# Altium schematic sheet Input Power Split Circuit.SchDoc
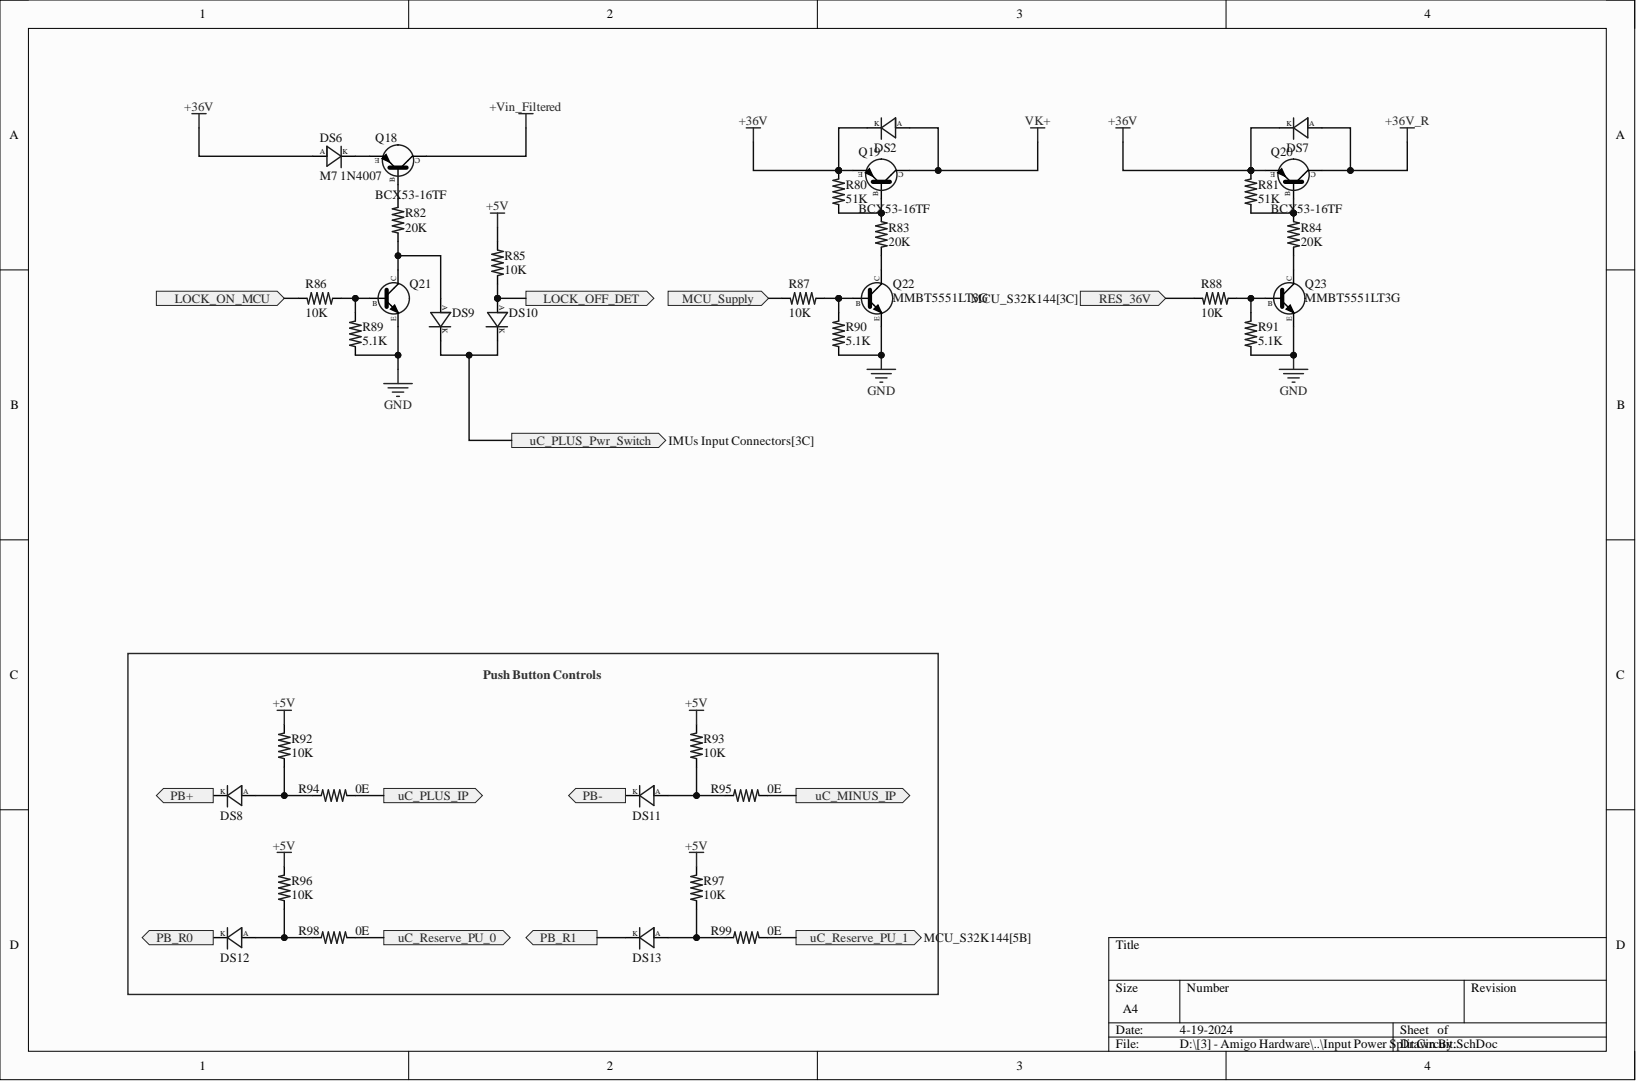
[diagram p0: Input Power Split Circuit.SchDoc]

## Parts
DS2  BAS416-HF  [SOD-323]  (value not drawn)
DS6  M7 1N4007  [DIO214AC]
DS7  BAS416-HF  [SOD-323]  (value not drawn)
DS8  BAS416-HF  [SOD-323]  (value not drawn)
DS9  BAS416-HF  [SOD-323]  (value not drawn)
DS10  BAS416-HF  [SOD-323]  (value not drawn)
DS11  BAS416-HF  [SOD-323]  (value not drawn)
DS12  BAS416-HF  [SOD-323]  (value not drawn)
DS13  BAS416-HF  [SOD-323]  (value not drawn)
Q18  BCX53-16TF  [SOT89]
Q19  BCX53-16TF  [SOT89]
Q20  BCX53-16TF  [SOT89]
Q21  MMBT5551LT3G  [SOT23]
Q22  MMBT5551LT3G  [SOT23]
Q23  MMBT5551LT3G  [SOT23]
R80  51K  [RES_0603]
R81  51K  [RES_0603]
R82  20K  [RES_0603]
R83  20K  [RES_0603]
R84  20K  [RES_0603]
R85  10K  [RES_0603]
R86  10K  [RES_0603]
R87  10K  [RES_0603]
R88  10K  [RES_0603]
R89  5.1K  [RES_0603]
R90  5.1K  [RES_0603]
R91  5.1K  [RES_0603]
R92  10K  [RES_0603]
R93  10K  [RES_0603]
R94  0E  [RES_0603]
R95  0E  [RES_0603]
R96  10K  [RES_0603]
R97  10K  [RES_0603]
R98  0E  [RES_0603]
R99  0E  [RES_0603]

## Ports
LOCK_OFF_DET, LOCK_ON_MCU, MCU_Supply, PB+, PB-, PB_R0, PB_R1, RES_36V, uC_MINUS_IP, uC_PLUS_IP, uC_PLUS_Pwr_Switch, uC_Reserve_PU_0, uC_Reserve_PU_1

## Nets
+5V: R85.1 R92.2 R93.2 R96.2 R97.2
+36V: DS2.2 DS6.1 DS7.2 Q19.3 Q20.3 R80.2 R81.2
+36V_R: DS7.1 Q20.2,4
+Vin_Filtered: Q18.2,4
GND: Q21.2 Q22.2 Q23.2 R89.1 R90.1 R91.1
VK+: DS2.1 Q19.2,4
LOCK_OFF_DET: DS10.1 R85.2 ; port LOCK_OFF_DET
LOCK_ON_MCU: R86.2 ; port LOCK_ON_MCU
MCU_Supply: R87.2 ; port MCU_Supply
PB_R0: DS12.2 ; port PB_R0
PB_R1: DS13.2 ; port PB_R1
RES_36V: R88.2 ; port RES_36V
uC_MINUS_IP: R95.2 ; port uC_MINUS_IP
uC_PLUS_IP: R94.2 ; port uC_PLUS_IP
uC_PLUS_Pwr_Switch: DS9.2 DS10.2 ; port uC_PLUS_Pwr_Switch
uC_Reserve_PU_0: R98.2 ; port uC_Reserve_PU_0
uC_Reserve_PU_1: R99.2 ; port uC_Reserve_PU_1
NetDS6_2: DS6.2 Q18.3
NetDS8_1: DS8.1 R92.1 R94.1
NetDS8_2: DS8.2
NetDS9_1: DS9.1 Q21.3 R82.1
NetDS11_1: DS11.1 R93.1 R95.1
NetDS11_2: DS11.2
NetDS12_1: DS12.1 R96.1 R98.1
NetDS13_1: DS13.1 R97.1 R99.1
NetQ18_1: Q18.1 R82.2
NetQ19_1: Q19.1 R80.1 R83.1
NetQ20_1: Q20.1 R81.1 R84.1
NetQ21_1: Q21.1 R86.1 R89.2
NetQ22_1: Q22.1 R87.1 R90.2
NetQ22_3: Q22.3 R83.2
NetQ23_1: Q23.1 R88.1 R91.2
NetQ23_3: Q23.3 R84.2
~{}:

## Library data of the parts
DS2 DS7-DS13 = DIO_REC_100V_200mA_SOD-323: Diode Switching 85V 200MA 250MW; Manufacturer: Comchip; Component Kind: Standard; Library Name: EM_LIBRARY_1.IntLib; Manufacturer Part Number: BAS416-HF; Pricing: 1=0.21, 10=0.146, 100=0.0711, 500=0.0593, 1000=0.04121 (USD); Stock: 2730; Supplier: DigiKey; Supplier Part Number: 641-BAS416-HFCT-ND
DS6 = DIO_RECT_1000V_1A_DIO214AC: Diode 1000 V 1A Surface Mount SMA/DO-214AC; Component Kind: Standard; Library Name: EM_LIBRARY_1.IntLib
Q18-Q20 = TRANS_PNP-80V_1A_SOT89: Transistor Gp Bjt PNP 80V 1A 3-PIN SC-62 T/r; Manufacturer: Nexperia; Category: Transistors; Component Kind: Standard; Library Name: EM_LIBRARY_1.IntLib; Manufacturer Part Number: BCX53-16TF; Pricing 1: 1=0.39, 10=0.276, 100=0.1394, 500=0.12356, 1000=0.09615, 2000=0.08606 (USD); RoHS: No; Stock 1: 14092; Supplier 1: Digi-Key; Supplier Part Number 1: 1727-BCX53-16TFCT-ND
Q21-Q23 = TRANS_NPN_160V_600mA_SOT23: NPN Ic=600mA Vceo=160V hfe=80~250 P=225mW SOT-23; Manufacturer: ON Semiconductor; Collector Base Voltage (VCBO): 180V; Collector Emitter Breakdown Voltage: 160V; Collector Emitter Saturation Voltage: 150mV; Collector-emitter Voltage-Max: 200mV; Current Rating: 600mA; Emitter Base Voltage (VEBO): 6V; hFE Min: 80; Max Power Dissipation: 225mW; Number of Elements: 1; Power Dissipation: 300mW; Case/Package: SOT-23-3; Category: BJTs; Collector Emitter Voltage (VCEO): 160V; Component Kind: Standard; ComponentLink1Description: https://datasheet.ciiva.com/4721/mmbt5550lt1-d-111393-4721331.pdf?src-supplier=Mouser; ComponentLink1URL: https://datasheet.ciiva.com/4721/mmbt5550lt1-d-111393-4721331.pdf?src-supplier=Mouser; ComponentLink2Description: https://datasheet.ciiva.com/15138/getdatasheetpartid-69307-15138969.pdf?src-supplier=Verical; ComponentLink2URL: https://datasheet.ciiva.com/15138/getdatasheetpartid-69307-15138969.pdf?src-supplier=Verical; ComponentLink3Description: https://datasheet.ciiva.com/46624/mmbt5550lt1-d-46624373.pdf?src-supplier=Digi-Key; ComponentLink3URL: https://datasheet.ciiva.com/46624/mmbt5550lt1-d-46624373.pdf?src-supplier=Digi-Key; Height: 1.11mm; Lead Free: Lead Free; Length: 3.04mm; Library Name: EM_LIBRARY_1.IntLib; Manufacturer Part Number: MMBT5551LT3G; Max Breakdown Voltage: 160V; Max Collector Current: 600mA; Max Operating Temperature: 150°C; Min Operating Temperature: -55°C; Number of Pins: 3; Package Quantity: 10000; Packaging: Tape and Reel; Polarity: NPN; Pricing 1: 1=0.17, 10=0.12, 100=0.065, 500=0.05104, 1000=0.03545, 2000=0.02937, 5000=0.02777 (USD); Radiation Hardening: No; RoHS: Yes; RoHS Compliant: Yes; Series: -; Stock 1: 6650; Supplier 1: Digi-Key; Supplier Part Number 1: MMBT5551LT3GOSCT-ND; Voltage Rating (DC): 160V; Width: 2.64mm
R80 R81 = RES_51K_0.1W_1%_0603: Fixed Resistor, Metal Glaze/thick Film, 0.1W, 51000ohm, 75V, 1% +/-Tol, 100ppm/Cel, Surface Mount, 0603; Manufacturer: Yageo; Manufacturer Part Number: RC0603FR-0751KL; Additional Feature: LASER TRIMMABLE; Package Height: 0.45mm; Package Length: 1.6mm; Package Width: 0.8mm; Power: 100mW; Rated Temperature: 70°C; Temperature Coefficient: 100ppm/°C; Value: 51kR; Case/Package: 0603; Category: Chip SMD Resistors; Component Kind: Standard; ComponentLink1Description: https://datasheet.ciiva.com:443/pdfs/VipMasterIC/IC/YAGO/YAGO-S-A0008145938/YAGO-S-A0008145938-1.pdf?src-supplier=IHS+Markit; ComponentLink1URL: https://datasheet.ciiva.com:443/pdfs/VipMasterIC/IC/YAGO/YAGO-S-A0008145938/YAGO-S-A0008145938-1.pdf?src-supplier=IHS+Markit; ComponentLink2Description: https://datasheet.ciiva.com/11819/0900766b80f96399-11819378.pdf?src-supplier=Rs; ComponentLink2URL: https://datasheet.ciiva.com/11819/0900766b80f96399-11819378.pdf?src-supplier=Rs; ComponentLink3Description: https://datasheet.ciiva.com/46395/443971-46395014.pdf?src-supplier=Element14; ComponentLink3URL: https://datasheet.ciiva.com/46395/443971-46395014.pdf?src-supplier=Element14; ComponentLink4Description: https://datasheet.ciiva.com/2454/pyu-r-int-thick-7-2454124.pdf?src-supplier=Digi-Key; ComponentLink4URL: https://datasheet.ciiva.com/2454/pyu-r-int-thick-7-2454124.pdf?src-supplier=Digi-Key; ComponentLink5Description: https://datasheet.ciiva.com/2454/pyu-r-int-thick-7-2454125.pdf?src-supplier=Digi-Key; ComponentLink5URL: https://datasheet.ciiva.com/2454/pyu-r-int-thick-7-2454125.pdf?src-supplier=Digi-Key; ComponentLink6Description: https://datasheet.ciiva.com/2454/pyu-r-int-thick-7-2454123.pdf?src-supplier=Digi-Key; ComponentLink6URL: https://datasheet.ciiva.com/2454/pyu-r-int-thick-7-2454123.pdf?src-supplier=Digi-Key; ComponentLink7Description: https://datasheet.ciiva.com/12558/rc0603-272842-12558554.pdf?src-supplier=Mouser; ComponentLink7URL: https://datasheet.ciiva.com/12558/rc0603-272842-12558554.pdf?src-supplier=Mouser; Construction: Chip; JESD-609 Code: e3; Library Name: EM_LIBRARY_1.IntLib; Max Operating Temperature: 155°C; Min Operating Temperature: -55°C; Mounting Technology: Surface Mount; Package Shape: Rectangular; Package Style: SMTMeter; Packaging: Tape and Reel; Pins: 2; Pricing: 1=0.1, 10=0.019, 50=0.0112, 100=0.0093, 500=0.00622, 1000=0.00532 (USD); Reach SVHC Compliant: Yes; Resistor Type: FIXED RESISTOR; RoHS: Yes; RoHS Compliant: Yes; Stock: 1453302; Supplier: Digi-Key; Supplier Part Number: 311-51.0KHRCT-ND; Technology: METAL GLAZE/THICK FILM; Terminal Finish: Nickel; Termination: Wraparound; Tolerance: 1%; Working Voltage: 75V
R82-R84 = RES_20K_1/10W_1%_0603: SMD Chip Resistor, 20 kOhm, ± 1%, 100 mW, 0603 [1608 Metric], Thick Film, General Purpose; Manufacturer 1: Yageo; Manufacturer Part Number 1: RC0603FR-1320KL; Category: Chip SMD Resistors; Component Kind: Standard; ComponentLink1Description: https://datasheet.ciiva.com/12561/rc0603-272842-12561998.pdf?src-supplier=Mouser; ComponentLink1URL: https://datasheet.ciiva.com/12561/rc0603-272842-12561998.pdf?src-supplier=Mouser; Library Name: EM_LIBRARY_1.IntLib; Pricing 1: 1=0.1, 10=0.018, 50=0.0106, 100=0.0088, 500=0.00592, 1000=0.00505, 5000=0.00363, 10000=0.00318 (USD); RoHS: Yes; Stock 1: 29997; Supplier 1: Digi-Key; Supplier Part Number 1: 13-RC0603FR-1320KLCT-ND
R85-R88 R92 R93 R96 R97 = RES_10K_0.1W_1%_0603: Fixed Resistor, Metal Glaze/thick Film, 0.1W, 10000ohm, 75V, 1% +/-Tol, 100ppm/Cel, Surface Mount, 0603; Manufacturer: Yageo; Manufacturer Part Number: RC0603FR-0710KL; Additional Feature: LASER TRIMMABLE; Package Height: 0.45mm; Package Length: 1.6mm; Package Width: 0.8mm; Power: 100mW; Rated Temperature: 70°C; Temperature Coefficient: 100ppm/°C; Value: 10kR; Case/Package: 0603; Category: Chip SMD Resistors; Component Kind: Standard; ComponentLink1Description: https://datasheet.ciiva.com:443/pdfs/VipMasterIC/IC/YAGO/YAGO-S-A0008145938/YAGO-S-A0008145938-1.pdf?src-supplier=IHS+Markit; ComponentLink1URL: https://datasheet.ciiva.com:443/pdfs/VipMasterIC/IC/YAGO/YAGO-S-A0008145938/YAGO-S-A0008145938-1.pdf?src-supplier=IHS+Markit; ComponentLink2Description: https://datasheet.ciiva.com/46440/1868787-46440891.pdf?src-supplier=Element14; ComponentLink2URL: https://datasheet.ciiva.com/46440/1868787-46440891.pdf?src-supplier=Element14; ComponentLink3Description: https://datasheet.ciiva.com/7746/1738695-7746239.pdf?src-supplier=Element14; ComponentLink3URL: https://datasheet.ciiva.com/7746/1738695-7746239.pdf?src-supplier=Element14; ComponentLink4Description: https://datasheet.ciiva.com/7983/443971-7983596.pdf?src-supplier=Element14; ComponentLink4URL: https://datasheet.ciiva.com/7983/443971-7983596.pdf?src-supplier=Element14; ComponentLink5Description: https://datasheet.ciiva.com/7660/38590-7660789.pdf?src-supplier=Element14; ComponentLink5URL: https://datasheet.ciiva.com/7660/38590-7660789.pdf?src-supplier=Element14; ComponentLink6Description: https://datasheet.ciiva.com/22747/443971-22747519.pdf?src-supplier=Element14; ComponentLink6URL: https://datasheet.ciiva.com/22747/443971-22747519.pdf?src-supplier=Element14; ComponentLink7Description: https://datasheet.ciiva.com/15189/getdatasheetpartid-409289-15189591.pdf?src-supplier=Verical; ComponentLink7URL: https://datasheet.ciiva.com/15189/getdatasheetpartid-409289-15189591.pdf?src-supplier=Verical; Construction: Chip; JESD-609 Code: e3; Library Name: EM_LIBRARY_1.IntLib; Max Operating Temperature: 155°C; Min Operating Temperature: -55°C; Mounting Technology: Surface Mount; Package Shape: Rectangular; Package Style: SMTMeter; Packaging: Tape and Reel; Pins: 2; Pricing: 1=0.1, 10=0.019, 50=0.0112, 100=0.0093, 500=0.00622, 1000=0.00532 (USD); Reach SVHC Compliant: Yes; Resistor Type: FIXED RESISTOR; RoHS: Yes; RoHS Compliant: Yes; Stock: 24542638; Supplier: Digi-Key; Supplier Part Number: 311-10.0KHRDKR-ND; Technology: METAL GLAZE/THICK FILM; Terminal Finish: Nickel; Termination: Wraparound; Tolerance: 1%; Working Voltage: 75V
R89-R91 = RES_5.1K_1/10W_5%_0603: SMD Chip Resistor, 5.1 kOhm, ± 5%, 100 mW, 0603 [1608 Metric], Thick Film, General Purpose; Manufacturer 1: Yageo; Manufacturer Part Number 1: RC0603JR-075K1L; Category: Chip SMD Resistors; Component Kind: Standard; ComponentLink1Description: http://www.farnell.com/datasheets/2167052.pdf?src-supplier=Farnell; ComponentLink1URL: http://www.farnell.com/datasheets/2167052.pdf?src-supplier=Farnell; ComponentLink2Description: http://www.mouser.com/ds/2/447/RC0603-272842.pdf?src-supplier=Mouser; ComponentLink2URL: http://www.mouser.com/ds/2/447/RC0603-272842.pdf?src-supplier=Mouser; ComponentLink3Description: http://www.farnell.com/datasheets/2167052.pdf?src-supplier=Element14; ComponentLink3URL: http://www.farnell.com/datasheets/2167052.pdf?src-supplier=Element14; ComponentLink4Description: http://www.farnell.com/datasheets/1868787.pdf?src-supplier=Newark; ComponentLink4URL: http://www.farnell.com/datasheets/1868787.pdf?src-supplier=Newark; ComponentLink5Description: https://datasheet.octopart.com/RC0603JR-075K1L-Yageo-datasheet-34211833.pdf?src-supplier=Element14; ComponentLink5URL: https://datasheet.octopart.com/RC0603JR-075K1L-Yageo-datasheet-34211833.pdf?src-supplier=Element14; ComponentLink6Description: https://datasheet.octopart.com/RC0603JR-075K1L-Yageo-datasheet-62067350.pdf?src-supplier=Farnell; ComponentLink6URL: https://datasheet.octopart.com/RC0603JR-075K1L-Yageo-datasheet-62067350.pdf?src-supplier=Farnell; ComponentLink7Description: http://www.farnell.com/datasheets/2167052.pdf?src-supplier=Newark; ComponentLink7URL: http://www.farnell.com/datasheets/2167052.pdf?src-supplier=Newark; ComponentLink8Description: http://www.yageo.com/documents/recent/PYu-RC_Group_51_RoHS_L_10.pdf?src-supplier=Digi-Key; ComponentLink8URL: http://www.yageo.com/documents/recent/PYu-RC_Group_51_RoHS_L_10.pdf?src-supplier=Digi-Key; ComponentLink9Description: https://datasheet.octopart.com/RC0603JR-075K1L-Yageo-datasheet-164759695.pdf?src-supplier=Future+Electronics; ComponentLink9URL: https://datasheet.octopart.com/RC0603JR-075K1L-Yageo-datasheet-164759695.pdf?src-supplier=Future+Electronics; ComponentLink10Description: http://www.yageo.com/documents/recent/PYu-RC0603_51_RoHS_L_7.pdf?src-supplier=Future+Electronics; ComponentLink10URL: http://www.yageo.com/documents/recent/PYu-RC0603_51_RoHS_L_7.pdf?src-supplier=Future+Electronics; Library Name: EM_LIBRARY_1.IntLib; Pricing 1: 1=0.1, 10=0.014, 50=0.0088, 100=0.0073, 500=0.00486, 1000=0.00415 (USD); RoHS: Yes; Stock 1: 372455; Supplier 1: Digi-Key; Supplier Part Number 1: 311-5.1KGRCT-ND
R94 R95 R98 R99 = RES_0E_0.1W_0603: Metal Glaze/thick Film, 0.1W, 0ohm, Surface Mount, 0603; Manufacturer: Yageo; Manufacturer Part Number: RC0603JR-070RL; Package Height: 0.45mm; Package Length: 1.6mm; Package Width: 0.8mm; Power: 100mW; Rated Temperature: 70°C; Value: 0R; Case/Package: 0603; Category: Chip SMD Resistors; Component Kind: Standard; ComponentLink1Description: https://datasheet.ciiva.com:443/pdfs/VipMasterIC/IC/YAGO/YAGO-S-A0008145938/YAGO-S-A0008145938-1.pdf?src-supplier=IHS+Markit; ComponentLink1URL: https://datasheet.ciiva.com:443/pdfs/VipMasterIC/IC/YAGO/YAGO-S-A0008145938/YAGO-S-A0008145938-1.pdf?src-supplier=IHS+Markit; Construction: Chip; JESD-609 Code: e3; Library Name: EM_LIBRARY_1.IntLib; Max Operating Temperature: 155°C; Min Operating Temperature: -55°C; Mounting Technology: Surface Mount; Package Shape: Rectangular; Package Style: SMTMeter; Packaging: Tape and Reel; Pins: 2; Pricing: 1=0.1, 10=0.015, 25=0.0112, 50=0.0086, 100=0.006, 250=0.0048, 500=0.00386, 1000=0.00284 (USD); Reach SVHC Compliant: Yes; Resistor Type: FIXED RESISTOR; RoHS: Yes; RoHS Compliant: Yes; Stock: 1005063; Supplier: Digi-Key; Supplier Part Number: 311-0.0GRCT-ND; Technology: METAL GLAZE/THICK FILM; Terminal Finish: Nickel; Termination: Wraparound
